# Altium schematic sheet power.SchDoc(power)
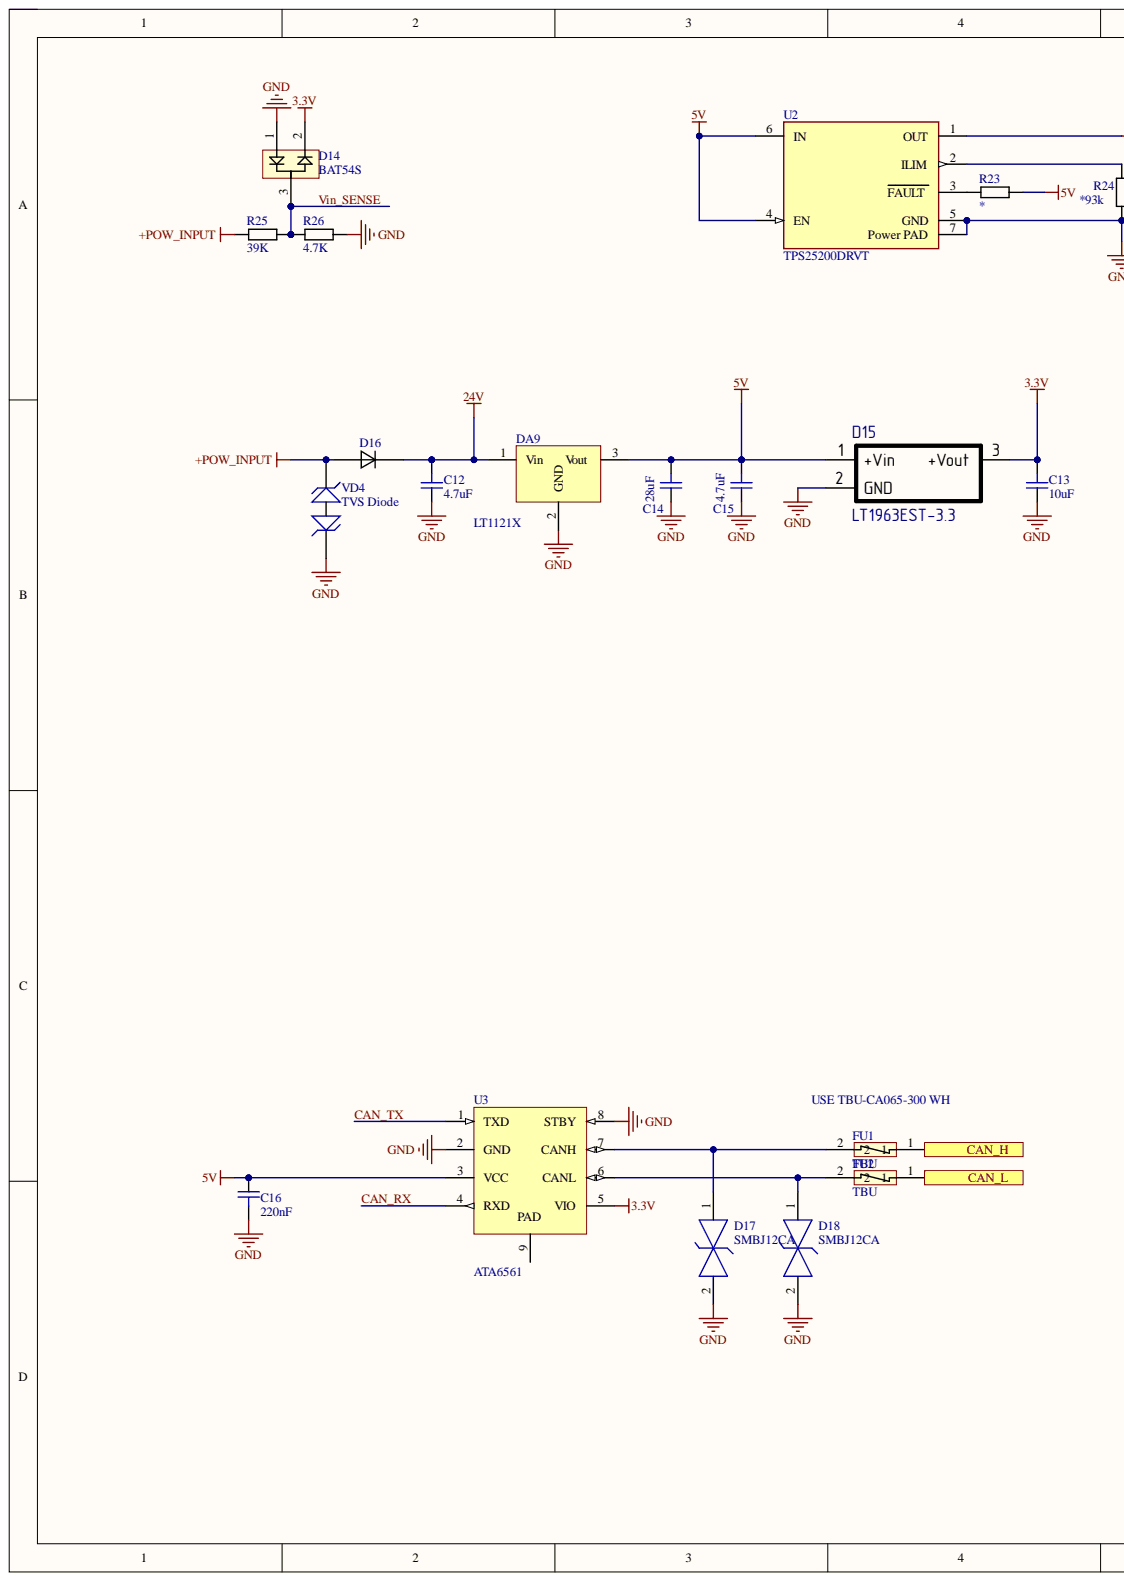
[diagram p0: power.SchDoc, left]
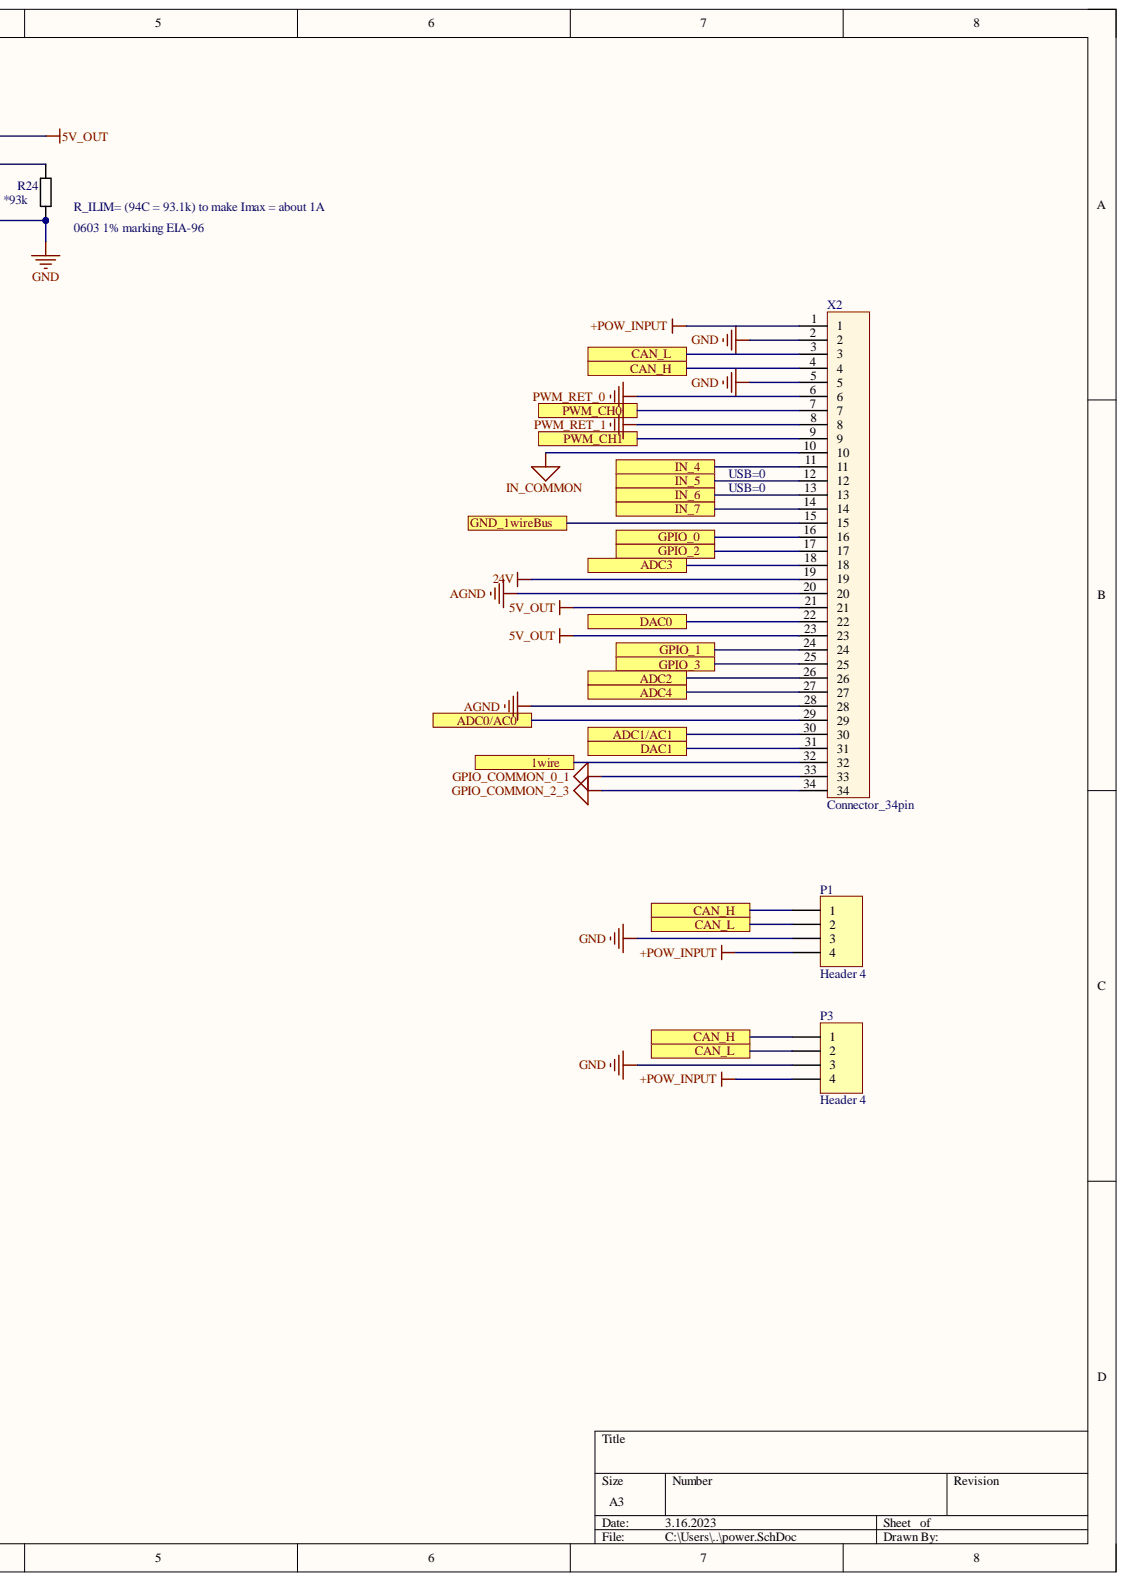
[diagram p1: power.SchDoc, right]

## Parts
C12  value 4.7uF  [CAPC3216M]  (hidden comment: Cap2)
C13  value 10uF  [CAPC3225M]  (hidden comment: Cap2)
C14  value 28uF  [CAPC5750M]  (hidden comment: Cap2)
C15  value 4.7uF  [CAPC3216L]  (hidden comment: Cap2)
C16  value 220nF  [CAPC2012M]  (hidden comment: Cap2)
D14  BAT54S  [SOT23-3L]
D15  LT1963EST-3.3  [SOT223]
D16  MMBZ5V6ALT3G  [SMB]  (value not drawn)
D17  SMBJ12CA  [FP-SMBJ-MFG]
D18  SMBJ12CA  [FP-SMBJ-MFG]
DA9  LT1121X  [SIP3]
FU1  TBU  [DFN TBU-CA]
FU2  TBU  [DFN TBU-CA]
P1  Header 4  [HDR1X4]
P3  Header 4  [HDR1X4]
R23  Res3  [J1-0603]  (value not drawn)
R24  value *93k  [J1-0603]  (hidden comment: Res3)
R25  value 39K  [RESC2012L]  (hidden comment: Res3)
R26  value 4.7K  [RESC2012L]  (hidden comment: Res3)
U2  TPS25200DRVT  [FP-DRV0006A-IPC_B]
U3  ATA6561  [SOIC-SN8_M]
VD4  TVS Diode  [DO-214AC]
X2  Connector_34pin  [TE 6437288-2]

## Ports
1wire, ADC0/AC0, ADC1/AC1, ADC2, ADC3, ADC4, CAN_H, CAN_L, DAC0, DAC1, GND_1wireBus, GPIO_0, GPIO_1, GPIO_2, GPIO_3, IN_4, IN_5, IN_6, IN_7, PWM_CH0, PWM_CH1

## Nets
3.3V: C13.2 D14.2 D15.3 U3.5(VIO)
5V: C14.2 C15.2 C16.1 D15.1 DA9.3 R23.1 U2.4(EN) U2.6(IN) U3.3(VCC)
5V_OUT: U2.1(OUT) X2.21 X2.23
24V: C12.2 D16.1 DA9.1 X2.19
+POW_INPUT: D16.2 P1.4 P3.4 R25.2 VD4.1 X2.1
AGND: X2.20 X2.28
GND: C12.1 C13.1 C14.1 C15.1 C16.2 D14.1 D15.2 D17.2 D18.2 DA9.2 P1.3 P3.3 R24.1 R26.1 U2.5(GND) U2.7(Power_PAD) U3.2(GND) U3.8(STBY) VD4.2 X2.2 X2.5
GPIO_COMMON_0_1: X2.33
GPIO_COMMON_2_3: X2.34
IN_COMMON: X2.10
PWM_RET_0: X2.6
PWM_RET_1: X2.8
1wire: X2.32 ; port 1wire
ADC0/AC0: X2.29 ; port ADC0/AC0
ADC1/AC1: X2.30 ; port ADC1/AC1
ADC2: X2.26 ; port ADC2
ADC3: X2.18 ; port ADC3
ADC4: X2.27 ; port ADC4
CAN_H: FU1.1 P1.1 P3.1 X2.4 ; port CAN_H
CAN_L: FU2.1 P1.2 P3.2 X2.3 ; port CAN_L
CAN_RX: U3.4(RXD)
CAN_TX: U3.1(TXD)
DAC0: X2.22 ; port DAC0
DAC1: X2.31 ; port DAC1
GND_1wireBus: X2.15 ; port GND_1wireBus
GPIO_0: X2.16 ; port GPIO_0
GPIO_1: X2.24 ; port GPIO_1
GPIO_2: X2.17 ; port GPIO_2
GPIO_3: X2.25 ; port GPIO_3
IN_4: X2.11 ; port IN_4
IN_5: X2.12 ; port IN_5
IN_6: X2.13 ; port IN_6
IN_7: X2.14 ; port IN_7
PWM_CH0: X2.7 ; port PWM_CH0
PWM_CH1: X2.9 ; port PWM_CH1
Vin_SENSE: D14.3 R25.1 R26.2
NetD17_1: D17.1 FU1.2 U3.7(CANH)
NetD18_1: D18.1 FU2.2 U3.6(CANL)
NetR23_2: R23.2 U2.3(FAULT)
NetR24_2: R24.2 U2.2(ILIM)

Not connected: U3 9

## Library data of the parts
C12-C16 = Cap2: Capacitor; Component Kind: Standard; Library Name: SA_AltiumSchLib.SchLib; PackageReference: CAPR5-4X5; Published: 23-Sep-2002; Signal Integrity: Cap; Simulation: CAP; Publisher: Altium Limited
D14 = BAT54S: Dual small signal Schottky diode; Forward Continious Current: 200 mA; Component Kind: Standard; Library Name: SA_AltiumSchLib.SchLib
D16 = Diode 1N4002_1: 1 Amp General Purpose Rectifier; Code_JEDEC: DO-204-AL; Component Kind: Standard; ComponentLink1Description: Manufacturer Link; ComponentLink1URL: http://www.fairchildsemi.com/; ComponentLink2Description: Datasheet; ComponentLink2URL: http://www.fairchildsemi.com/ds/1N%2F1N4001.pdf; DatasheetVersion: 1998; LatestRevisionDate: 17-Jul-2002; LatestRevisionNote: Re-released for DXP Platform.; Library Name: SA_AltiumSchLib.SchLib; PackageReference: DO-41; PackageVersion: Aug-1999; Published: 8-Jun-2000; Signal Integrity: 1N4002; Simulation: 1N4002; Publisher: Altium Limited
D17 D18 = CMP-08607-000016-1: TVS DIODE 12V 19.9V DO214AA; Manufacturer: Littelfuse Inc; Breakdown Voltage: 13.3V; Direction: Bidirectional; Max Junction Temp: +150°C; Number Of Channels: 1; Peak Pulse Current: 30.2A; Peak Pulse Power: 600W; Automotive: No; Category: Diode; Clamping Voltage: 19.9V; Component Kind: Standard; ComponentLink2Description: Datasheet; ComponentLink2URL: https://www.littelfuse.com/~/media/electronics/datasheets/tvs_diodes/littelfuse_tvs_diode_smbj_datasheet.pdf.pdf; Device Class L1: Circuit Protection; Device Class L2: TVS Diodes; Device Class L3: unset; Digikey Description: TVS DIODE 12V 19.9V DO214AA; Height: 2.61mm; Lead Free: Yes; Library Name: Altium Content Vault; Manufacturer Part Number: SMBJ12CA; Mouser Description: TVS Diodes - Transient Voltage Suppressors 12Vr 600W 30.2A 5% BiDirectional; Octopart: https://octopart.com/smbj12ca-littelfuse-33212; Package: SMBJ; Reverse Standoff Voltage: 12V; RoHS: TRUE; Temperature: -65°C to +150°C; Temperature Range High: +150°C; Temperature Range Low: -65°C
DA9 = R-78XX: 0.5-1.5 dc/dc RECOM voltage regulator; Component Kind: Standard; Library Name: SA_AltiumSchLib.SchLib
FU1 FU2 = TBU-CA GUARD: TBU-CA Series - TBU® High-Speed Protectors; Component Kind: Standard; Library Name: SA_AltiumSchLib.SchLib
P1 P3 = Header 4: Header, 4-Pin; Component Kind: Standard; LatestRevisionDate: 17-Jul-2002; LatestRevisionNote: Re-released for DXP Platform.; Library Name: Miscellaneous Connectors.IntLib; Signal Integrity: Connector; Publisher: Altium Limited
R23-R26 = Res3: Resistor; Component Kind: Standard; LatestRevisionDate: 29-May-2009; LatestRevisionNote: IPC-7351 Footprint Added.; Library Name: SA_AltiumSchLib.SchLib; PackageDescription: Chip Resistor; PackageReference: J1-0603; Published: 8-Jun-2000; Signal Integrity: Res; Simulation: RESISTOR; Publisher: Altium Limited
U2 = CMP-04913-000017-1: IC PWR MGR EFUSE 6.5V 2.8A 6SON; Current: 2.5A; Min Supply Voltage: 2.5V; Number of Channels: 1; Component Kind: Standard; Contact Plating: Gold; Features: Auto Retry; Height: 0.75mm; Lead Free: Lead Free; Length: 2.1mm; Library Name: Altium Content Vault; Max Operating Temperature: 125°C; Max Output Current: 2.5A; Max Supply Voltage: 6.5V; Min Operating Temperature: -40°C; Mount: Surface Mount; Number of Outputs: 1; Number of Pins: 6; Packaging: Cut Tape; REACH SVHC: No SVHC; RoHS Compliant: Yes; Voltage: 6.5V; Width: 2.1mm
U3 = ATA6561: FD ready CAN Transceiver, 8-Pin 3.3/5V; Component Kind: Standard; Library Name: SA_AltiumSchLib.SchLib
D15 = LT1963EST-3.3: Component Kind: Standard; Library Name: SA_AltiumSchLib.SchLib; Type: LT1963EST-3.3
VD4 = TVS Diode: Component Kind: Standard; Description: TVS Diode; Library Name: SA_AltiumSchLib.SchLib
X2 = Connector_34pin: Component Kind: Standard; Library Name: SA_AltiumSchLib.SchLib
